# Altium schematic sheet robot.SchDoc
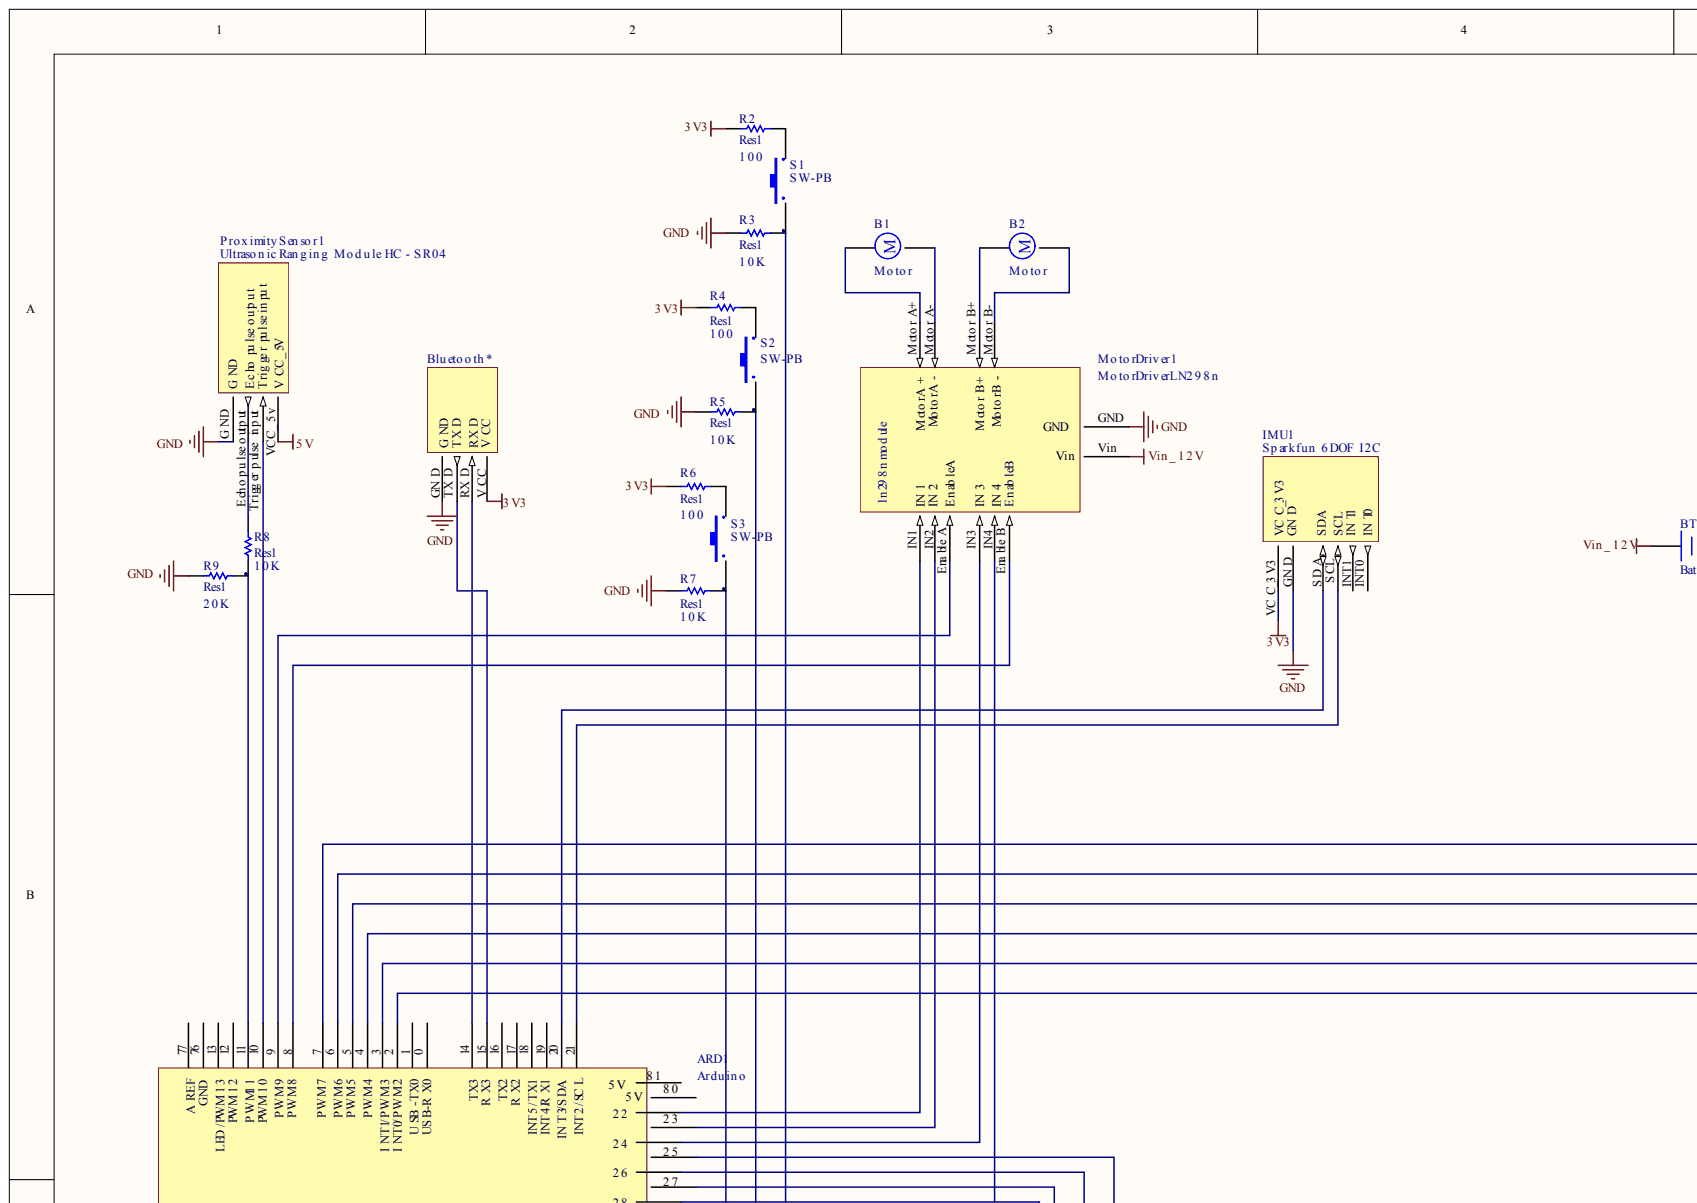
[diagram p0: robot.SchDoc, top left]
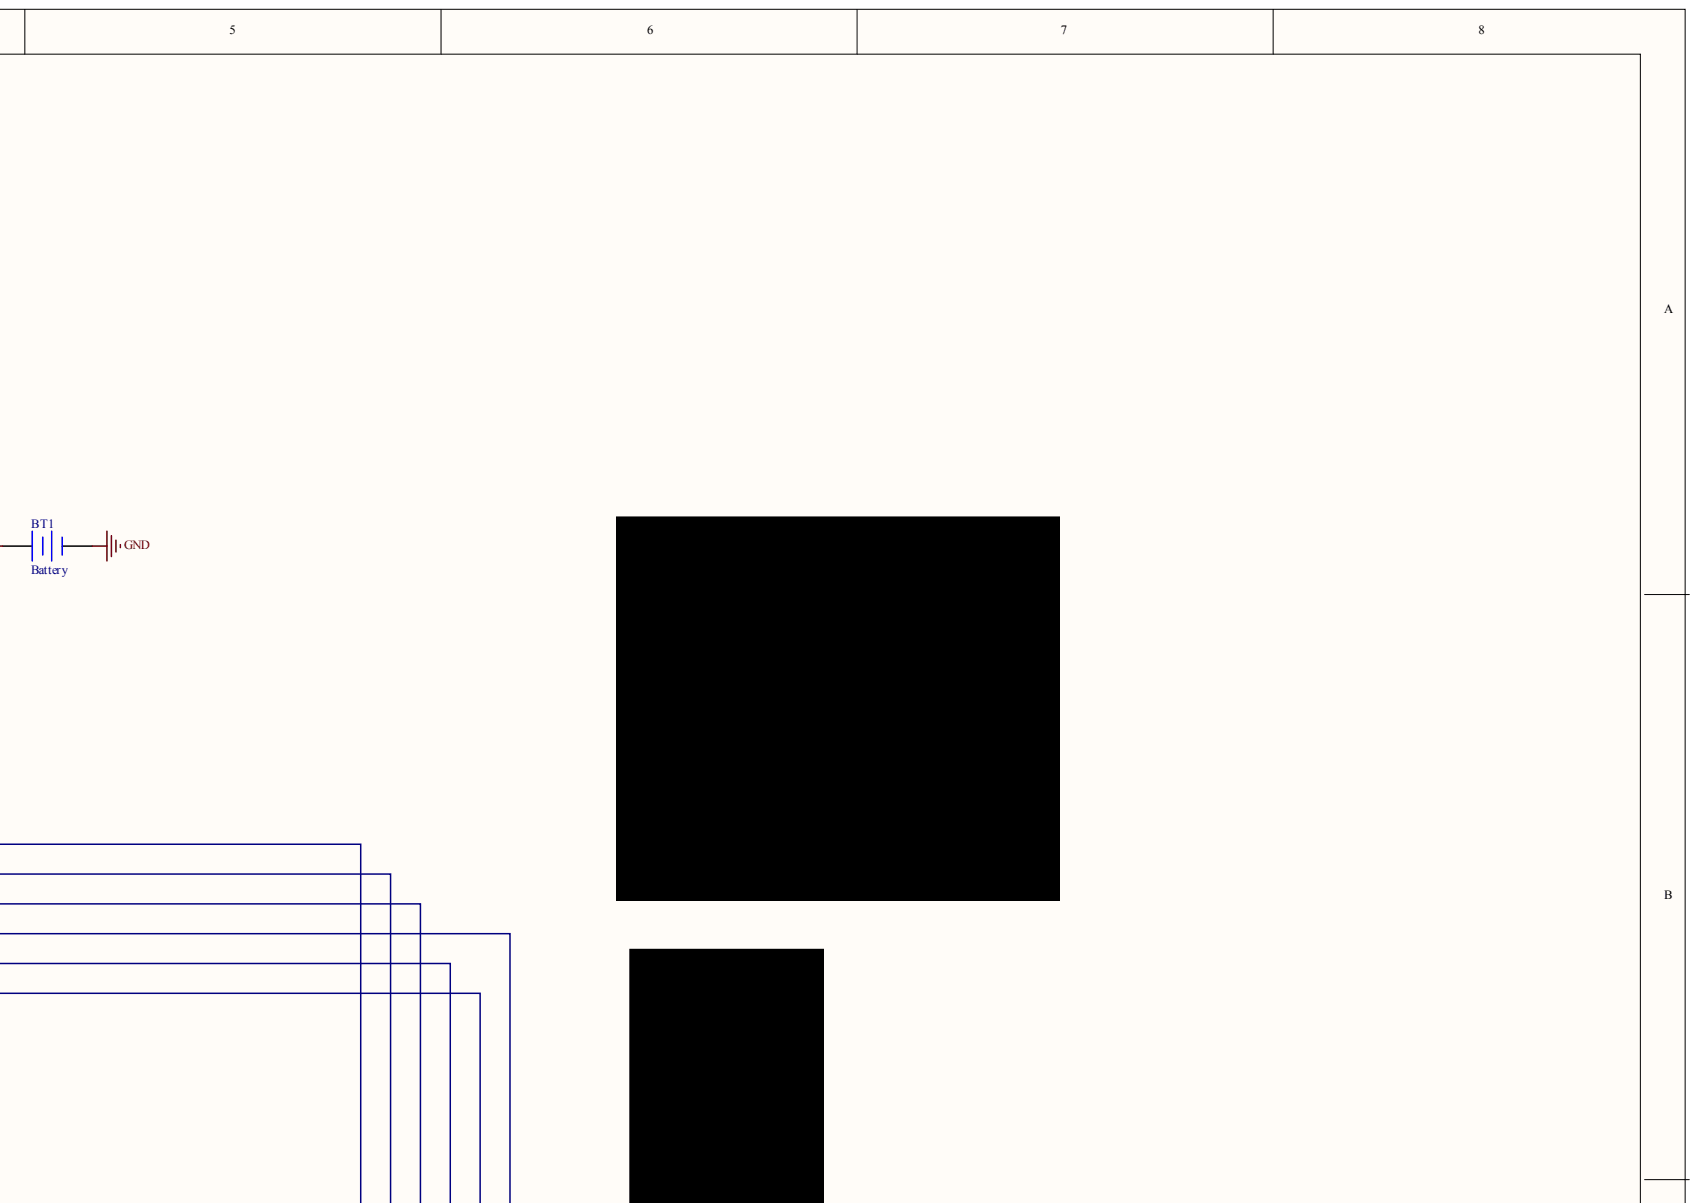
[diagram p1: robot.SchDoc, top right]
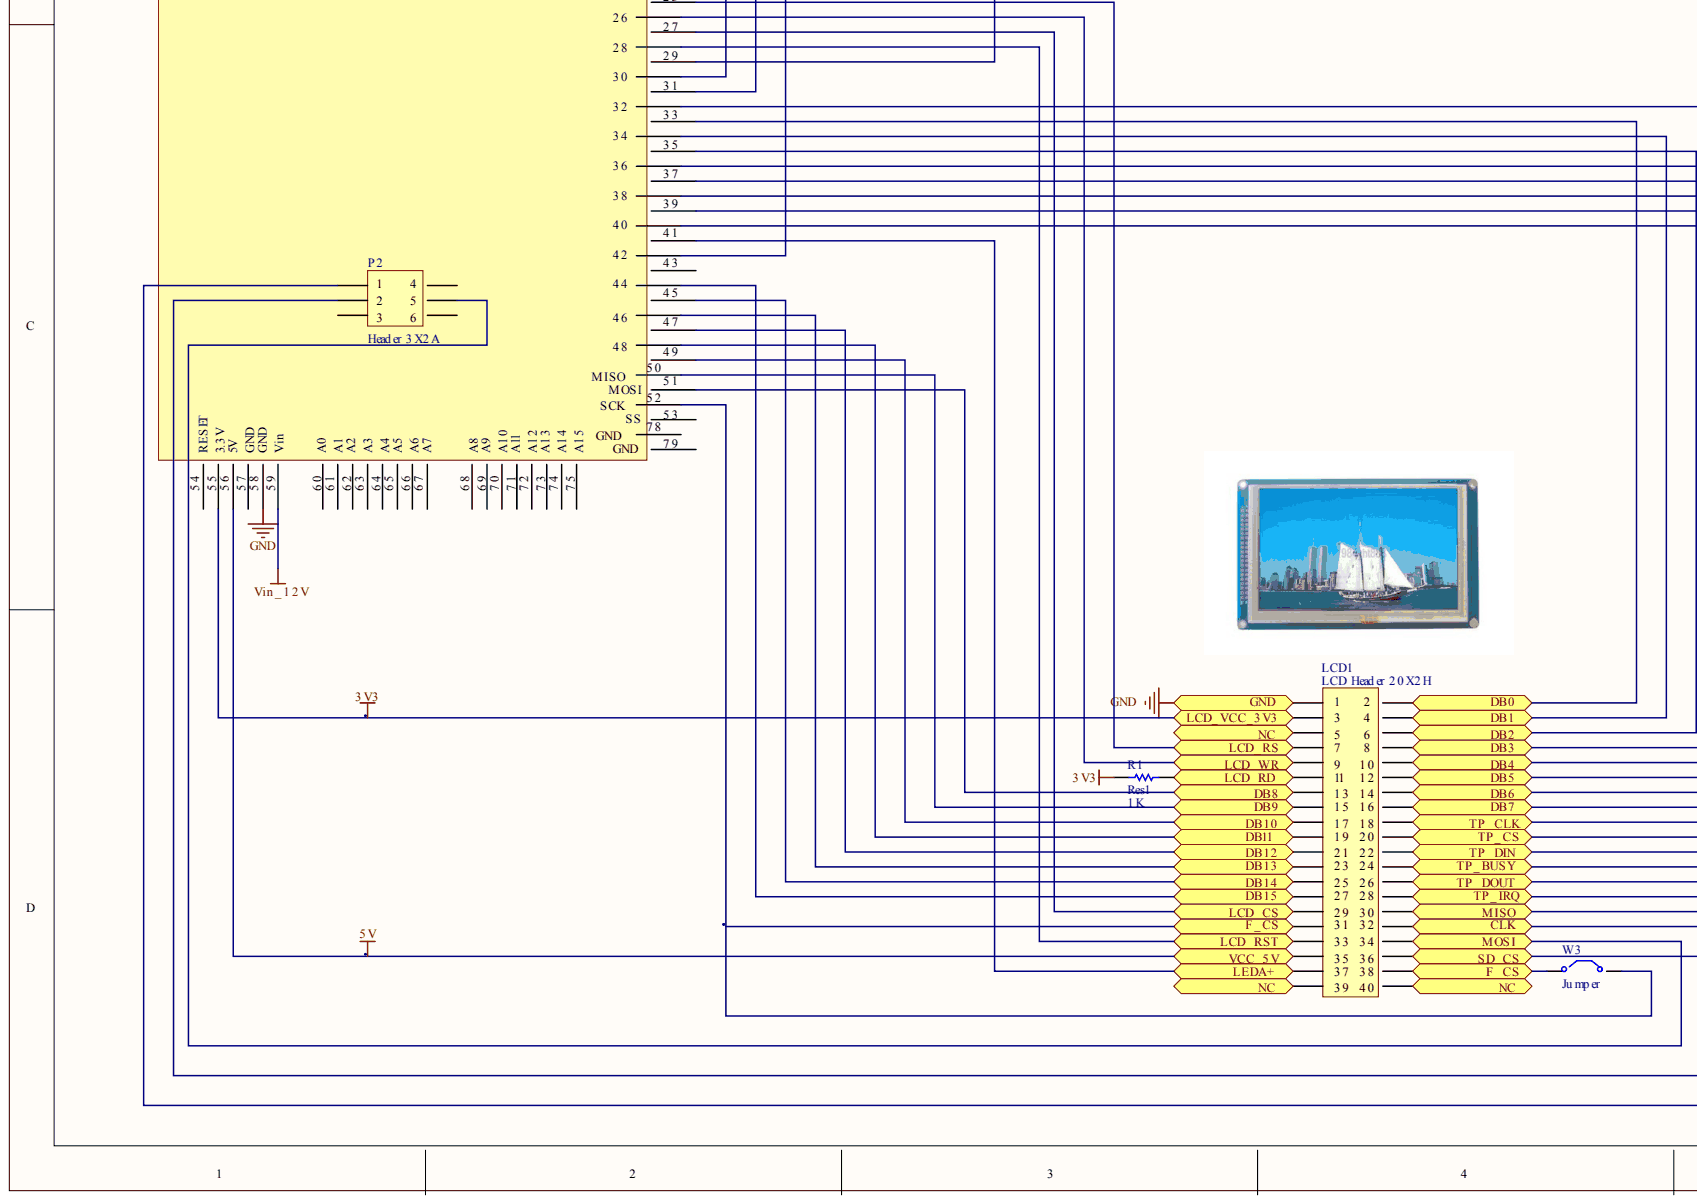
[diagram p2: robot.SchDoc, bottom left]
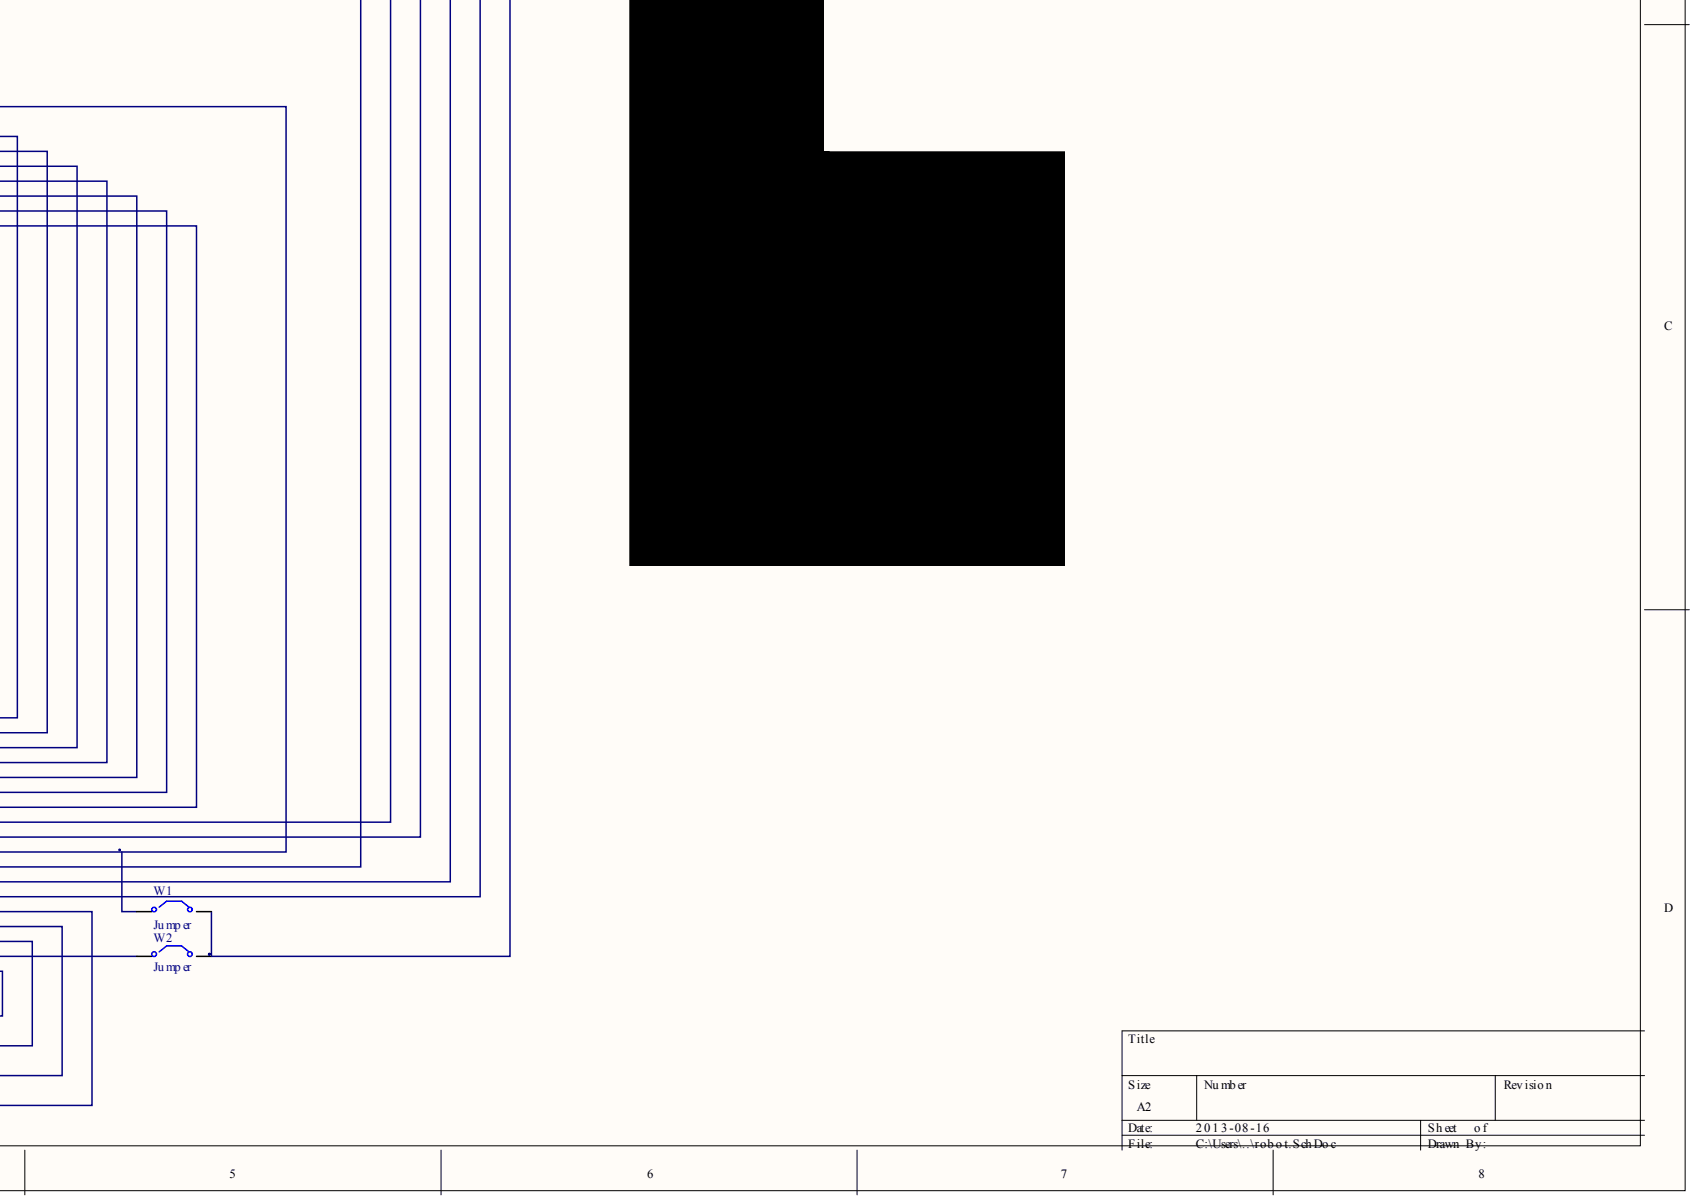
[diagram p3: robot.SchDoc, bottom right]

## Parts
ARD1  Arduino
B1  Motor
B2  Motor
Bluetooth*  Generic 4pin Bluetooth module  (value not drawn)
BT1  Battery
IMU1  Sparkfun 6DOF I2C
LCD1  LCD Header 20X2H
MotorDriver1  MotorDriverLN298n
P2  Header 3X2A
ProximitySensor1  Ultrasonic Ranging Module HC - SR04
R1  Res1  value 1K
R2  Res1  value 100
R3  Res1  value 10K
R4  Res1  value 100
R5  Res1  value 10K
R6  Res1  value 100
R7  Res1  value 10K
R8  Res1  value 10K
R9  Res1  value 20K
S1  SW-PB
S2  SW-PB
S3  SW-PB
W1  Jumper
W2  Jumper
W3  Jumper

## Ports
CLK, DB0, DB1, DB2, DB3, DB4, DB5, DB6, DB7, DB8, DB9, DB10, DB11, DB12, DB13, DB14, DB15, F_CS, GND, LCD_CS, LCD_RD, LCD_RS, LCD_RST, LCD_VCC_3V3, LCD_WR, LEDA+, MISO, MOSI, NC, SD_CS, TP_BUSY, TP_CLK, TP_CS, TP_DIN, TP_DOUT, TP_IRQ, VCC_5V

## Nets
VIN_12V: ARD1.59 BT1.1 MotorDriver1.Vin
3V3: ARD1.55 Bluetooth*.VCC(V_CC) IMU1.VCC_3V3 LCD1.3 R1.1 R2.2 R4.2 R6.2 ; port LCD_VCC_3V3
5V: ARD1.56(5V) LCD1.35 ProximitySensor1.VCC_5v ; port VCC_5V
CLK: LCD1.32 P2.2 ; port CLK
DB0: ARD1.33 LCD1.2 ; port DB0
DB1: ARD1.34 LCD1.4 ; port DB1
DB2: ARD1.35 LCD1.6 ; port DB2
DB3: ARD1.36 LCD1.8 ; port DB3
DB4: ARD1.37 LCD1.10 ; port DB4
DB5: ARD1.38 LCD1.12 ; port DB5
DB6: ARD1.39 LCD1.14 ; port DB6
DB7: ARD1.40 LCD1.16 ; port DB7
DB8: ARD1.51(M_OS_I) LCD1.13 ; port DB8
DB9: ARD1.50(M_ISO) LCD1.15 ; port DB9
DB10: ARD1.49 LCD1.17 ; port DB10
DB11: ARD1.48 LCD1.19 ; port DB11
DB12: ARD1.47 LCD1.21 ; port DB12
DB13: ARD1.46 LCD1.23 ; port DB13
DB14: ARD1.45 LCD1.25 ; port DB14
DB15: ARD1.44 LCD1.27 ; port DB15
F_CS: ARD1.52(S_CK) LCD1.31 LCD1.38 W3.1 W3.2 ; port F_CS
GND: ARD1.58(GND) Bluetooth*.GND BT1.2 IMU1.GND(GN_D) LCD1.1 MotorDriver1.GND ProximitySensor1.GND(G_ND) R3.1 R5.1 R7.1 R9.2 ; port GND
LCD_CS: ARD1.27 LCD1.29 ; port LCD_CS
LCD_RD: LCD1.11 R1.2 ; port LCD_RD
LCD_RS: ARD1.25 LCD1.7 ; port LCD_RS
LCD_RST: ARD1.28 LCD1.33 ; port LCD_RST
LCD_WR: ARD1.26 LCD1.9 ; port LCD_WR
LEDA+: ARD1.41 LCD1.37 ; port LEDA+
MISO: LCD1.30 P2.1 ; port MISO
MOSI: LCD1.34 P2.5 ; port MOSI
NC: LCD1.5 LCD1.39 LCD1.40 ; port NC
SD_CS: LCD1.36 W2.1 ; port SD_CS
TP_BUSY: ARD1.7(P_WM_7) LCD1.24 ; port TP_BUSY
TP_CLK: ARD1.6 LCD1.18 ; port TP_CLK
TP_CS: ARD1.5(P_WM_5) LCD1.20 ; port TP_CS
TP_DIN: ARD1.32 LCD1.22 W1.1 ; port TP_DIN
TP_DOUT: ARD1.3 LCD1.26 ; port TP_DOUT
TP_IRQ: ARD1.2(I_NT0/P_WM_2) LCD1.28 ; port TP_IRQ
NetARD1_4: ARD1.4 W1.2 W2.2
NetARD1_8: ARD1.8(P_WM_8) MotorDriver1.EnableB(En_ab_leB)
NetARD1_9: ARD1.9 MotorDriver1.EnableA
NetARD1_10: ARD1.10(PWM_1_0) ProximitySensor1.Trigger pulse input(Trig_ge_r_pu_lse_in_pu_t)
NetARD1_11: ARD1.11 R8.1 R9.1
NetARD1_14: ARD1.14 Bluetooth*.RXD
NetARD1_15: ARD1.15(R_X3) Bluetooth*.TXD(TX_D)
NetARD1_20: ARD1.20 IMU1.SDA(S_DA)
NetARD1_21: ARD1.21 IMU1.SCL
NetARD1_22: ARD1.22 MotorDriver1.IN1
NetARD1_23: ARD1.23 MotorDriver1.IN2(IN_2)
NetARD1_24: ARD1.24 MotorDriver1.IN3(IN_3)
NetARD1_29: ARD1.29 MotorDriver1.IN4(IN_4)
NetARD1_30: ARD1.30 R7.2 S3.1
NetARD1_31: ARD1.31 R5.2 S2.1
NetARD1_42: ARD1.42 R3.2 S1.1
NetB1_1: B1.1 MotorDriver1.Motor A+
NetB1_2: B1.2 MotorDriver1.Motor A-
NetB2_1: B2.1 MotorDriver1.Motor B+(M_oto_r_B+)
NetB2_2: B2.2 MotorDriver1.Motor B-
NetProximitySensor1_Echo pulse output: ProximitySensor1.Echo pulse output R8.2
NetR2_1: R2.1 S1.2
NetR4_1: R4.1 S2.2
NetR6_1: R6.1 S3.2

Not connected: ARD1 0(US_B-R_X0) 1 12(PWM_1_2) 13 16 17(R_X2) 18 19(INT4_/R_X1) 43 53(SS) 54 57 60(A0) 61 62(A2) 63 64 65(A5) 66 67(A7) 68 69(A9) 70 71(A11) 72 73(A1_3) 74 75 76 77 78(GND) 79(GND) 80(5V) 81(5V); IMU1 INT0(IN_T0) INT1(IN_T1); P2 3 4 6

## Library data of the parts
R2 R4 R6 = (no symbol name): Value: 100; Published: 8-Jun-2000; LatestRevisionDate: 17-Jul-2002; LatestRevisionNote: Re-released for DXP Platform.; PackageReference: AXIAL-0.3; PackageDescription: Axial Device, Thru-Hole; 2 Leads; 0.3 in Pin Spacing; Publisher: Altium Limited
ARD1 B1 B2 Bluetooth* BT1 IMU1 LCD1 MotorDriver1 P2 ProximitySensor1 R1 R3 R5 R7-R9 S1-S3 W1-W3 = (no symbol name)
  B1 B2: Published: 24-Mar-1999; LatestRevisionDate: 17-Jul-2002; LatestRevisionNote: Re-released for DXP Platform.; PackageReference: RB5-10.5; PackageDescription: Capacitor (Radial); 2 Leads
  BT1: Published: 24-Mar-1999; LatestRevisionDate: 17-Jul-2002; LatestRevisionNote: Re-released for DXP Platform.; PackageReference: BAT-2; PackageDescription: Battery; 2 Leads
  LCD1 P2: LatestRevisionDate: 17-Jul-2002; LatestRevisionNote: Re-released for DXP Platform.
  R1 R3 R5 R7-R9: Published: 8-Jun-2000; LatestRevisionDate: 17-Jul-2002; LatestRevisionNote: Re-released for DXP Platform.; PackageReference: AXIAL-0.3; PackageDescription: Axial Device, Thru-Hole; 2 Leads; 0.3 in Pin Spacing
  S1-S3: Published: 24-Mar-1999; LatestRevisionDate: 17-Jul-2002; LatestRevisionNote: Re-released for DXP Platform.; PackageReference: SPST-2; PackageDescription: Switch; 2 Leads
  W1-W3: Published: 24-Mar-1999; LatestRevisionDate: 17-Jul-2002; LatestRevisionNote: Re-released for DXP Platform.; PackageReference: RAD-0.2; PackageDescription: Radial Cap, Thru-Hole; 2 Leads; 0.2 in Pin Spacing
  B1 B2 BT1 LCD1 P2 R1 R3 R5 R7-R9 S1-S3 W1-W3: Publisher: Altium Limited
